# Altium schematic sheet IO.SchDoc(IO)
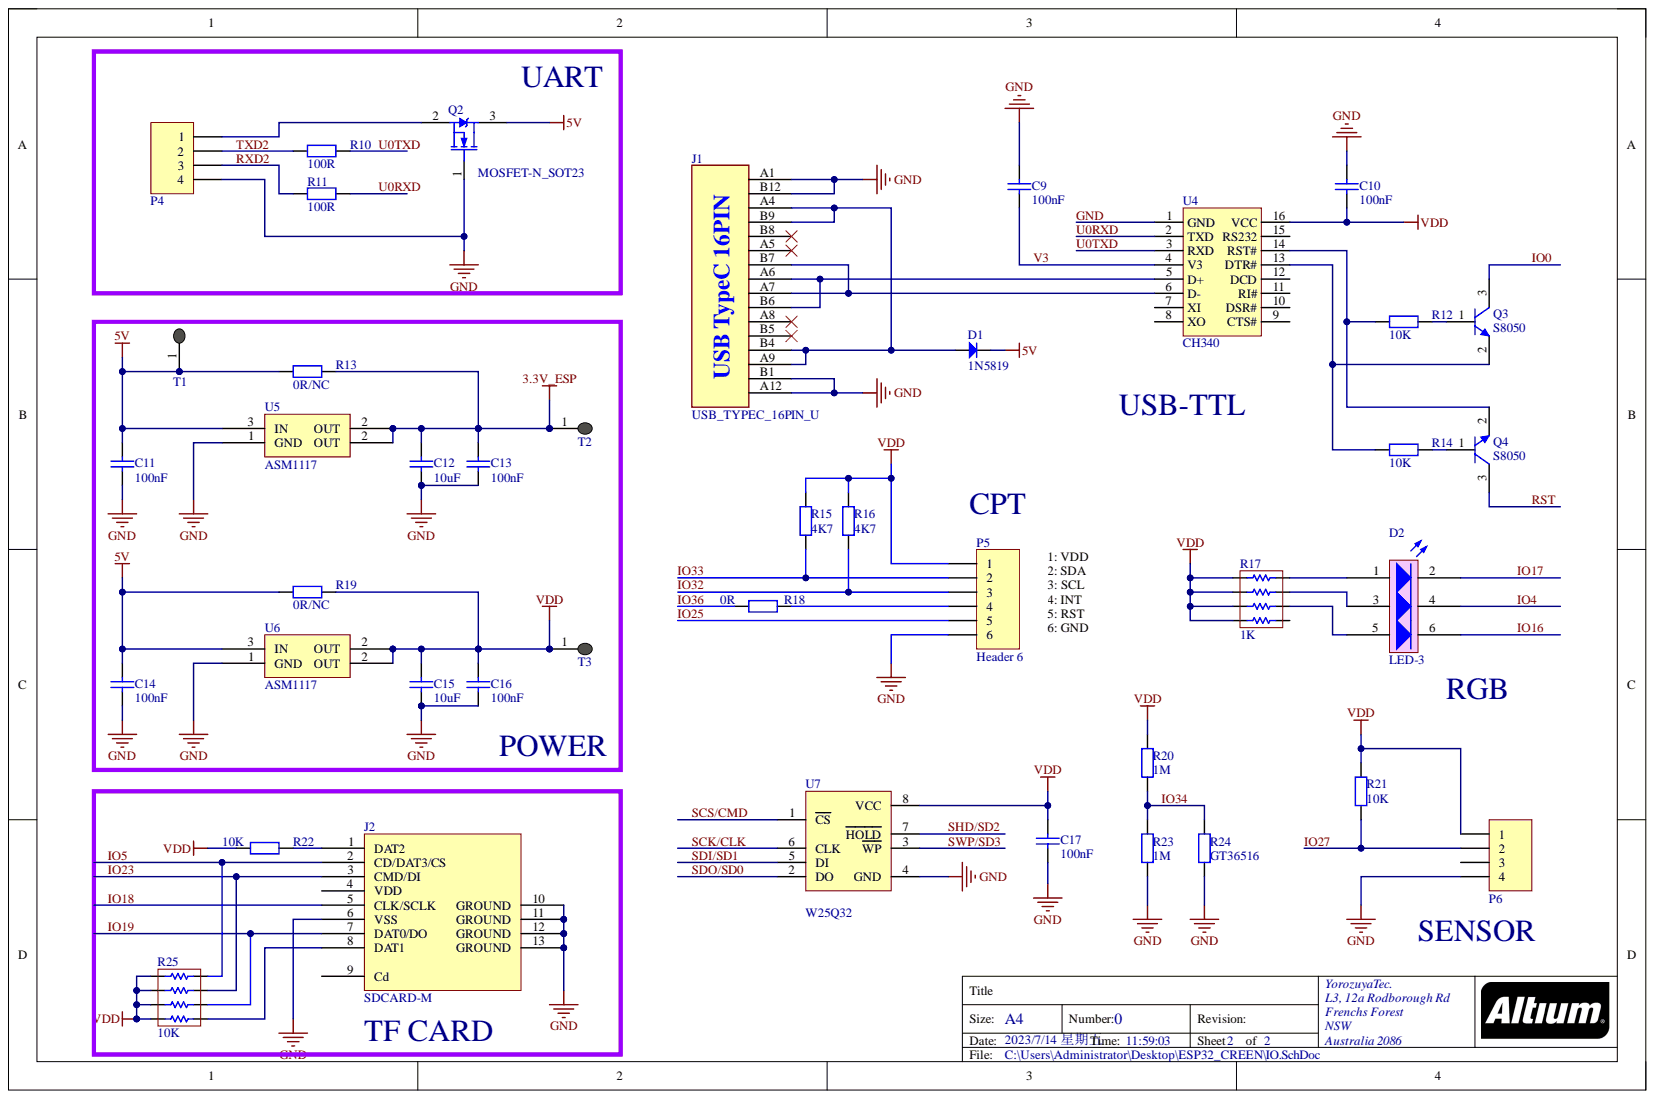
[diagram p0: IO.SchDoc]

## Parts
C9  value 100nF  [C0603]  (hidden comment: Cap)
C10  value 100nF  [C0603]  (hidden comment: Cap)
C11  value 100nF  [C0603]  (hidden comment: Cap)
C12  value 10uF  [C0603]  (hidden comment: Cap)
C13  value 100nF  [C0603]  (hidden comment: Cap)
C14  value 100nF  [C0603]  (hidden comment: Cap)
C15  value 10uF  [C0603]  (hidden comment: Cap)
C16  value 100nF  [C0603]  (hidden comment: Cap)
C17  value 100nF  [C0603]  (hidden comment: Cap)
D1  1N5819  [SOD323]
D2  LED-3  [LED5050]
J1  USB_TYPEC_16PIN_U  [USB-TYPE-C_16PIN-MALE-TYPE-C-31-M-12]
J2  SDCARD-M  [SDCARD-M]
P4  Header 4  [MX1.25S-4P]  (value not drawn)
P5  Header 6  [FPC0.5-6P]
P6  Header 4  [MX1.25S-4P]  (value not drawn)
Q2  MOSFET-N_SOT23  [SOT23]
Q3  S8050  [SOT23]
Q4  S8050  [SOT23]
R10  value 100R  [R0603]  (hidden comment: Res1)
R11  value 100R  [R0603]  (hidden comment: Res1)
R12  value 10K  [R0603]  (hidden comment: Res1)
R13  value 0R/NC  [R0805]  (hidden comment: Res1)
R14  value 10K  [R0603]  (hidden comment: Res1)
R15  value 4K7  [R0603]  (hidden comment: Res1)
R16  value 4K7  [R0603]  (hidden comment: Res1)
R17  value 1K  [8P4R-0603]  (hidden comment: RPot)
R18  value 0R  [R0603]  (hidden comment: Res1)
R19  value 0R/NC  [R0805]  (hidden comment: Res1)
R20  value 1M  [R0603]  (hidden comment: Res1)
R21  value 10K  [R0603]  (hidden comment: Res1)
R22  value 10K  [R0603]  (hidden comment: Res1)
R23  value 1M  [R0603]  (hidden comment: Res1)
R24  value GT36516  [R2010]  (hidden comment: Res1)
R25  value 10K  [8P4R-0603]  (hidden comment: RPot)
T1  TEST  [TEST PAD]  (value not drawn)
T2  TEST  [TEST PAD]  (value not drawn)
T3  TEST  [TEST PAD]  (value not drawn)
U4  CH340  [SOP16]
U5  ASM1117  [SOT-89]
U6  ASM1117  [SOT-89]
U7  W25Q32  [SOIC8_W]

## Nets
3.3V_ESP: C12.2 C13.2 R13.2 T2.1 U5.2
5V: C11.2 C14.2 D1.2 Q2.3 R13.1 R19.1 T1.1 U5.3 U6.3
VDD: C10.1 C15.2 C16.2 C17.2 P5.1 P6.1 R15.2 R16.2 R17.1 R17.2 R17.3 R17.4 R19.2 R20.2 R21.2 R22.1 R25.1 R25.2 R25.3 R25.4 T3.1 U4.16(VCC) U6.2 U7.8(VCC)
GND: C9.2 C10.2 C11.1 C12.1 C13.1 C14.1 C15.1 C16.1 C17.1 J1.A1 J1.A12 J1.B1 J1.B12 J2.6(VSS) J2.10(GROUND) J2.11(GROUND) J2.12(GROUND) J2.13(GROUND) P4.4 P5.6 P6.4 Q2.1 R23.1 R24.1 U4.1(GND) U5.1 U6.1 U7.4(GND)
IO0: Q3.3
IO4: D2.4
IO5: J2.2(CD/DAT3/CS) R25.8
IO16: D2.6
IO17: D2.2
IO18: J2.5(CLK/SCLK)
IO19: J2.7(DAT0/DO) R25.6
IO23: J2.3(CMD/DI) R25.7
IO25: P5.5
IO27: P6.2 R21.1
IO32: P5.3 R16.1
IO33: P5.2 R15.1
IO34: R20.1 R23.2 R24.2
IO36: R18.2
RST: Q4.3
RXD2: P4.3 R11.1
SCK/CLK: U7.6(CLK)
SCS/CMD: U7.1(CS)
SDI/SD1: U7.5(DI)
SDO/SD0: U7.2(DO)
SHD/SD2: U7.7(HOLD)
SWP/SD3: U7.3(WP)
TXD2: P4.2 R10.1
U0RXD: R11.2 U4.2(TXD)
U0TXD: R10.2 U4.3(RXD)
V3: C9.1 U4.4(V3)
NetD1_1: D1.1 J1.A4 J1.A9 J1.B4 J1.B9
NetD2_1: D2.1 R17.8
NetD2_3: D2.3 R17.7
NetD2_5: D2.5 R17.6
NetJ1_A6: J1.A6 J1.B6 U4.5(D+)
NetJ1_A7: J1.A7 J1.B7 U4.6(D-)
NetJ2_1: J2.1(DAT2) R22.2
NetJ2_8: J2.8(DAT1) R25.5
NetP4_1: P4.1 Q2.2
NetP5_4: P5.4 R18.1
NetQ3_1: Q3.1 R12.2
NetQ3_2: Q3.2 R14.1 U4.13(DTR#)
NetQ4_1: Q4.1 R14.2
NetQ4_2: Q4.2 R12.1 U4.14(RST#)

Not connected: J1 A5 A8 B5 B8; J2 4(VDD) 9(Cd); P6 3; R17 5; U4 7(XI) 8(XO) 9(CTS#) 10(DSR#) 11(RI#) 12(DCD) 15(RS232)

## Library data of the parts
C9-C17 = Cap: 贴片电容; Component Kind: Standard; LatestRevisionDate: 17-Jul-2002; LatestRevisionNote: Re-released for DXP Platform.; PackageReference: RAD-0.3; Published: 8-Jun-2000; Library Name: Sunny20181114.SchLib; Publisher: Altium Limited
D1 = Diode: 二极管; Code_JEDEC: DO-214-AB; Component Kind: Standard; LatestRevisionDate: 17-Jul-2002; LatestRevisionNote: Re-released for DXP Platform.; PackageDocument: Sep-1996; PackageReference: SMC; Published: 8-Jun-2000; Library Name: Sunny20181114.SchLib; Publisher: Altium Limited
D2 = LED-3: 三色LED; Component Kind: Standard; LatestRevisionDate: 08-Jul-2005; LatestRevisionNote: Mech Layer 1 removed.; PackageReference: LED-0; Published: 8-Jun-2000; Library Name: Sunny20181114.SchLib; Publisher: Altium Limited
J1 = USB_TYPEC_16PIN_U: USB_TYPEC_16PIN 母座; Component Kind: Standard; Library Name: Sunny20181114.SchLib
J2 = SDCARD-M: TF卡槽; Component Kind: Standard; Library Name: Sunny20181114.SchLib
P4 P6 = Header 4: 接插件; Component Kind: Standard; LatestRevisionDate: 17-Jul-2002; LatestRevisionNote: Re-released for DXP Platform.; Library Name: Sunny20181114.SchLib; Publisher: Altium Limited
P5 = Header 6: 接插件; Component Kind: Standard; LatestRevisionDate: 17-Jul-2002; LatestRevisionNote: Re-released for DXP Platform.; Library Name: Sunny20211020.SchLib; Publisher: Altium Limited
Q2 = MOSFET-N_SOT23: PackageDocument: 1995/1996; Component Kind: Standard; Description: MOSFET-N_SOT23; LatestRevisionDate: 17-Jul-2002; LatestRevisionNote: Re-released for DXP Platform.; PackageReference: E3; Published: 8-Jun-2000; Library Name: Sunny20181114.SchLib; Publisher: Altium Limited
Q3 Q4 = S8050: NPN三极管; Code_JEDEC: TO-92A; Component Kind: Standard; ComponentLink1Description: Manufacturer Link; ComponentLink1URL: http://www.fairchildsemi.com/; ComponentLink2Description: Datasheet; ComponentLink2URL: http://www.fairchildsemi.com/ds/2N%2F2N3904.pdf; DatasheetDocument: 1997; LatestRevisionDate: 15-Jan-2003; LatestRevisionNote: PCB Footprint 'BCY-W3/D4.7' replaced; PackageDocument: Sep-1998; PackageReference: TO-92A; Published: 8-Jun-2000; Library Name: Sunny20181114.SchLib; Publisher: Altium Limited
R10-R16 R18-R24 = Res1: 贴片电阻; Component Kind: Standard; LatestRevisionDate: 17-Jul-2002; LatestRevisionNote: Re-released for DXP Platform.; PackageReference: AXIAL-0.4; Published: 8-Jun-2000; Library Name: Sunny20181114.SchLib; Publisher: Altium Limited
R17 R25 = RES-PZ: 排电阻; Set Position: 0.5; Sim Note: Enter the Resistance value and the set point into parameter fields.; Component Kind: Standard; LatestRevisionDate: 17-Jul-2002; LatestRevisionNote: Re-released for DXP Platform.; PackageReference: VR5; Published: 8-Jun-2000; Library Name: Sunny20181114.SchLib; Publisher: Altium Limited
T1-T3 = TEST测试点: 测试点; Code_JEDEC: DO-214-AB; Component Kind: Standard; LatestRevisionDate: 17-Jul-2002; LatestRevisionNote: Re-released for DXP Platform.; PackageDocument: Sep-1996; PackageReference: SMC; Published: 8-Jun-2000; Library Name: Sunny20181114.SchLib; Publisher: Altium Limited
U4 = CH340: CH340 USB转串口芯片; Component Kind: Standard; Library Name: Sunny20181114.SchLib
U5 U6 = ASM1117: 3.3V稳压芯片; Component Kind: Standard; Library Name: Sunny20181114.SchLib
U7 = W25QXX: SPI FLASH; Component Kind: Standard; Library Name: Sunny20181114.SchLib
